# Altium schematic sheet probe.SchDoc
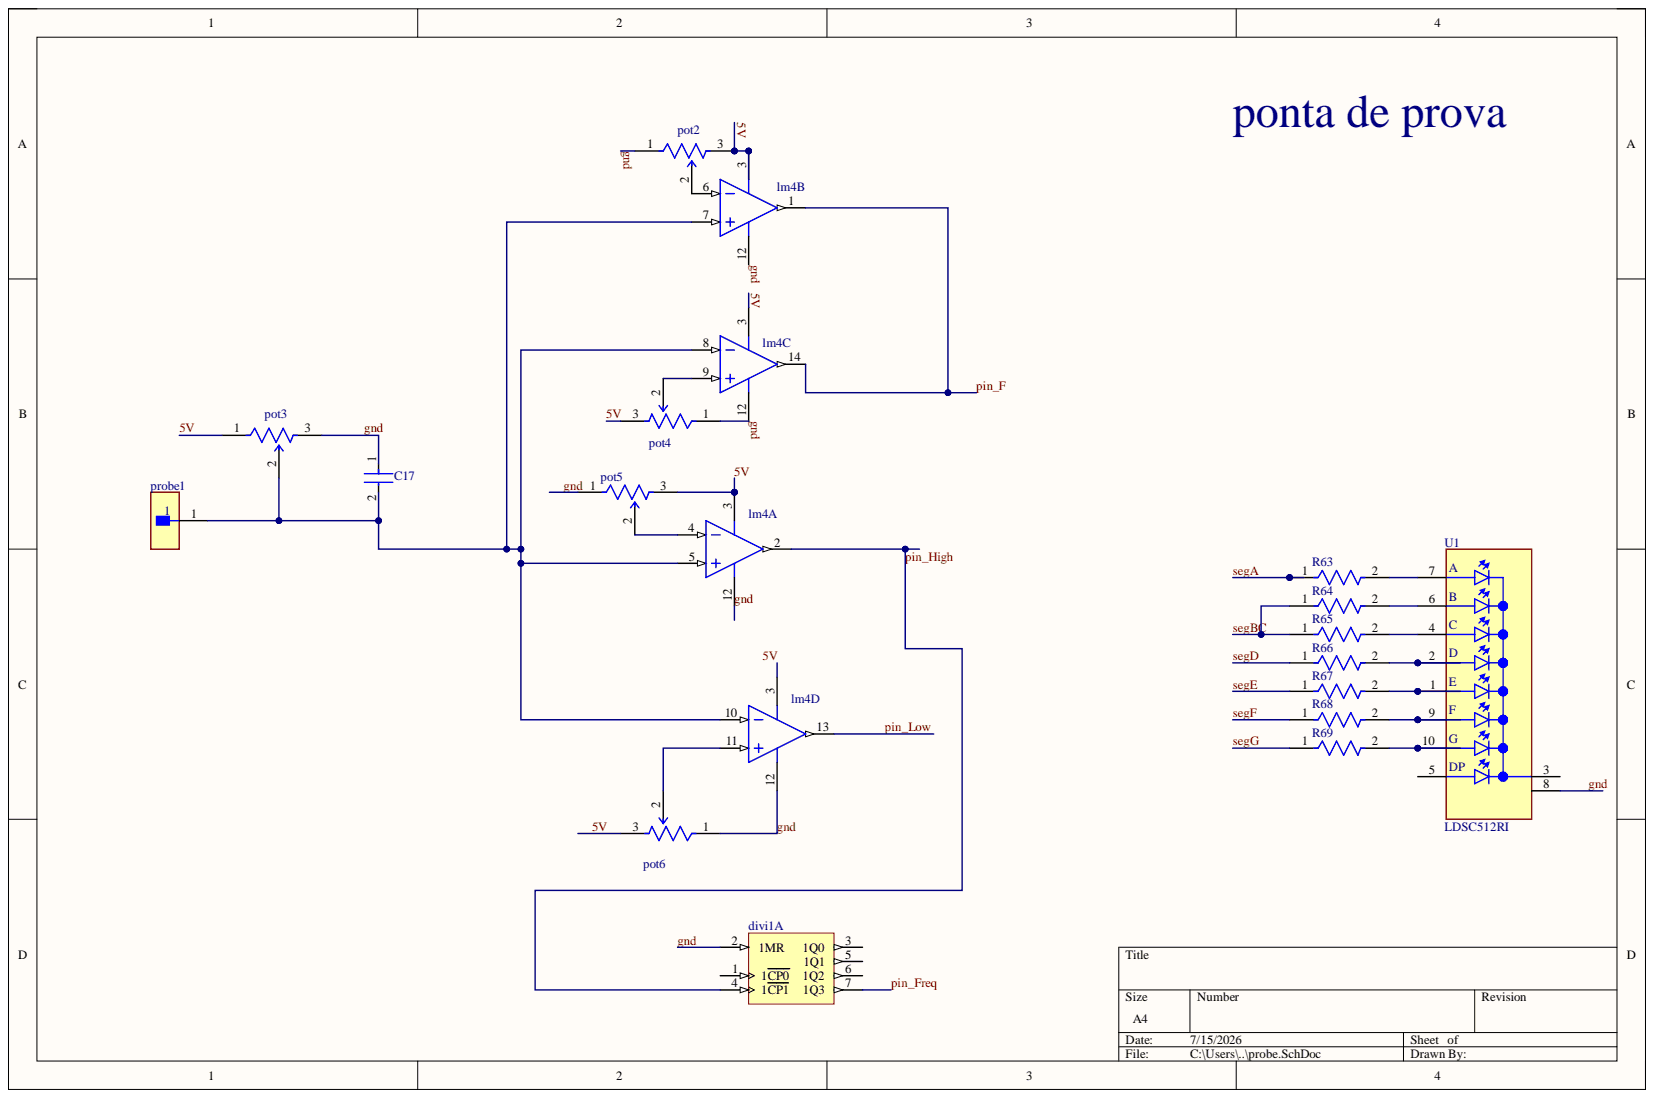
[diagram p0: probe.SchDoc]

## Parts
C17  RCER71H335K3K1H03B  [FP-RCE3M1-MFG]  (value not drawn)
divi1  CD74HC390E  [N0016A]  (value not drawn)  (part A)
lm4  LM339J  [J0014A]  (value not drawn)  (parts A,B,C,D)
pot2  3296W-1-501LF  [FP-3296W-MFG]  (value not drawn)
pot3  3296W-1-501LF  [FP-3296W-MFG]  (value not drawn)
pot4  3296W-1-501LF  [FP-3296W-MFG]  (value not drawn)
pot5  3296W-1-501LF  [FP-3296W-MFG]  (value not drawn)
pot6  3296W-1-501LF  [FP-3296W-MFG]  (value not drawn)
probe1  TSW-101-07-L-S  [FP-TSW-101-07-L-S-MFG]  (value not drawn)
R63  MRS16000C2002FCT00  [FP-MRS1600-MFG]  (value not drawn)
R64  MRS16000C2002FCT00  [FP-MRS1600-MFG]  (value not drawn)
R65  MRS16000C2002FCT00  [FP-MRS1600-MFG]  (value not drawn)
R66  MRS16000C2002FCT00  [FP-MRS1600-MFG]  (value not drawn)
R67  MRS16000C2002FCT00  [FP-MRS1600-MFG]  (value not drawn)
R68  MRS16000C2002FCT00  [FP-MRS1600-MFG]  (value not drawn)
R69  MRS16000C2002FCT00  [FP-MRS1600-MFG]  (value not drawn)
U1  LDSC512RI  [LED_LDSC512RI]

## Nets
5V: lm4.3 pot2.3 pot3.1 pot4.3 pot5.3 pot6.3
gnd: C17.1 divi1.2(1MR) lm4.12 pot2.1 pot3.3 pot4.1 pot5.1 pot6.1 U1.8
pin_F: lm4.1 lm4.14
pin_Freq: divi1.7(1Q3)
pin_High: divi1.4(1CP1) lm4.2
pin_Low: lm4.13
segA: R63.1
segBC: R64.1 R65.1
segD: R66.1
segE: R67.1
segF: R68.1
segG: R69.1
NetC17_2: C17.2 lm4.5 lm4.7 lm4.8 lm4.10 pot3.2 probe1.1
Netlm4_4: lm4.4 pot5.2
Netlm4_6: lm4.6 pot2.2
Netlm4_9: lm4.9 pot4.2
Netlm4_11: lm4.11 pot6.2
NetR63_2: R63.2 U1.7
NetR64_2: R64.2 U1.6
NetR65_2: R65.2 U1.4
NetR66_2: R66.2 U1.2
NetR67_2: R67.2 U1.1
NetR68_2: R68.2 U1.9
NetR69_2: R69.2 U1.10

Not connected: divi1 1(1CP0) 3(1Q0) 5(1Q1) 6(1Q2); U1 3 5

## Library data of the parts
C17 = CMP-06035-028451-1: Cap Ceramic 3.3uF 50V X7R ±10% Radial 5mm +125°C Automotive Ammo Pack; Lead Diameter: 0.5mm; Lead/Base Style: Formed Leads; Capacitance: 3.3uF; Case/Package: Radial; Component Kind: Standard; Dielectric: X7R; Height: 5mm; Height - Seated (Max): 0.197inch; Lead Pitch: 0.197inch; Length: 0.217inch; Library Name: Altium Content Vault; Max Operating Temperature: 125°C; Min Operating Temperature: -55°C; Mount: Through Hole; Package Quantity: 500; Packaging: Bulk; Ratings: AEC-Q200; Resistor Type: Ceramic; Termination: Radial; Tolerance: 10%; Voltage Rating: 50V; Voltage Rating (DC): 50V; Width: 0.157inch
divi1 = CMP-1623-00557-2: High Speed CMOS Logic Dual Decade Ripple Counters, N0016A, TUBE; Manufacturer: Texas Instruments; Bits(#): 4; F @ Nom Voltage(Max)(Mhz): 28; ICC @ Nom Voltage(Max)(mA): 0.08; Input Type: LVTTL/CMOS; Inputs: 1; Output Drive (IOL/IOH)(Max)(mA): 5.2/-5.2; Rating: Catalog; Schmitt Trigger: No; Technology Family: HC; tpd @ Nom Voltage(Max)(ns): 52; VCC(Max)(V): 6; VCC(Min)(V): 2; Voltage(Nom)(V): 3.3,5; Code_JEDEC: MS-001; Component Kind: Standard; DatasheetVersion: SCHS185C; Function: Counter; Generic Part Number: CD74HC390; Library Name: Altium Content Vault; Operating Temperature Range(C): -55 to 125; Output Type: CMOS; PackageReference: N0016A; Type: Decade
lm4 = CMP-0060-00012-3: Low Power Low Offset Voltage Quad Comparator, 14-pin CerDIP; Manufacturer: Texas Instruments; PackageTopMarking: NSUZXYYTT*LM339J; PowerWise: N; Component Kind: Standard; ComponentLink1Description: Datasheet URL; ComponentLink1URL: http://www.ti.com/general/docs/lit/getliterature.tsp?genericPartNumber=LM339-N&fileType=pdf; CompType: Comparator; Library Name: Altium Content Vault; PackageDescription: Cerdip, .400 x .310in Body, 14 Lead, .300in Centers, .100in Pitch; PackageReference: J0014A; PinCount: 14; RoHS: N
pot2 pot4-pot6 = CMP-07234-000046-1: TRIMMER 500 OHM 0.5W PC PIN TOP; Max Power Dissipation: 500mW; Number of Turns: 25; Resistance: 10kR; Resistance Tolerance: 10%; Temperature Coefficient: 100ppm/°C; Color: Blue; Component Kind: Standard; Contact Plating: Tin; Depth: 4.83mm; Height: 0.395inch; Lead Free: Lead Free; Length: 9.53mm; Library Name: Altium Content Vault; Max Operating Temperature: 125°C; Military Standard: Not; Min Operating Temperature: -55°C; Mount: Through Hole; Package Quantity: 50; Packaging: Rail/Tube; Power Rating: 500mW; Radiation Hardening: No; REACH SVHC: No SVHC; Resistor Type: Cermet; RoHS Compliant: Yes; Series: 3296; Taper: Linear; Technology: CERMET; Termination: Pin; Tolerance: 10%; Weight: 0.03oz; Width: 9.53mm
pot3 = CMP-07234-000046-1: TRIMMER 500 OHM 0.5W PC PIN TOP; Max Power Dissipation: 500mW; Number of Turns: 25; Resistance: 100kR; Resistance Tolerance: 10%; Temperature Coefficient: 100ppm/°C; Color: Blue; Component Kind: Standard; Contact Plating: Tin; Depth: 4.83mm; Height: 0.395inch; Lead Free: Lead Free; Length: 9.53mm; Library Name: Altium Content Vault; Max Operating Temperature: 125°C; Military Standard: Not; Min Operating Temperature: -55°C; Mount: Through Hole; Package Quantity: 50; Packaging: Rail/Tube; Power Rating: 500mW; Radiation Hardening: No; REACH SVHC: No SVHC; Resistor Type: Cermet; RoHS Compliant: Yes; Series: 3296; Taper: Linear; Technology: CERMET; Termination: Pin; Tolerance: 10%; Weight: 0.03oz; Width: 9.53mm
probe1 = CMP-02766-001775-1: CONN HEADER VERT 1POS; Gender: Male; Max Current Rating: 7.6A; Max Voltage Rating (AC): 550V; Number of Contacts: 1; Color: Black; Component Kind: Standard; Connector Type: Header; Contact Finish - Mating: GOLD; Contact Material: Bronze; Contact Mating Length: 0.23inch; Contact Plating: Gold; Contact Shape: Square; Contact Type: Male Pin; Depth: 2.54mm; Fastening Type: Pull; Flammability Rating: UL94 V-0; Housing Color: Black; Housing Material: Polyester; Insulation Color: Black; Insulation Material: PBT; Lead Free: Lead Free; Length: 2.54mm; Library Name: Altium Content Vault; Max Operating Temperature: 125°C; Min Operating Temperature: -55°C; Mount: Through Hole; Number of Positions: 1; Number of Rows: 1; Orientation: Straight; Packaging: Bulk; Radiation Hardening: No; Reach SVHC Compliant: Yes; RoHS Compliant: Yes; Series: TSW; Termination: Solder; Width: 2.54mm
R63-R69 = CMP-02408-000019-1: RES 300 OHM 0.4W 1% AXIAL; Max Power Dissipation: 400mW; Resistance: 20kR; Temperature Coefficient: 50ppm/°C; Case/Package: Conformal; Component Kind: Standard; Construction: Cylindrical; Contact Plating: Tin; Diameter: 1.6mm; Length: 3.6mm; Library Name: Altium Content Vault; Max Operating Temperature: 155°C; Military Standard: Not; Min Operating Temperature: -55°C; Mount: Through Hole; Number of Terminations: 2; Packaging: Tape and Reel; Power Rating: 400mW; Radiation Hardening: No; Resistor Type: Metal Film; RoHS Compliant: Yes; Series: MRS16; Technology: THIN FILM; Termination: Axial; Tolerance: 1%
U1 = LDSC512RI: .56IN. 7 SEGMENT SINGLE DISPLAY, 565NM GREEN, GRAY FACE, WHITE SEGMENTS, COMMON | Lumex LDS-C512RI; Check_prices: https://www.snapeda.com/parts/LDS-C512RI/Lumex/view-part/?ref=eda; Component Kind: Standard; Library Name: LDSC512RI.SchLib; MF: Lumex; MP: LDS-C512RI; Package: None; SnapEDA_Link: https://www.snapeda.com/parts/LDS-C512RI/Lumex/view-part/?ref=snap
